# Altium schematic sheet GPS.SchDoc
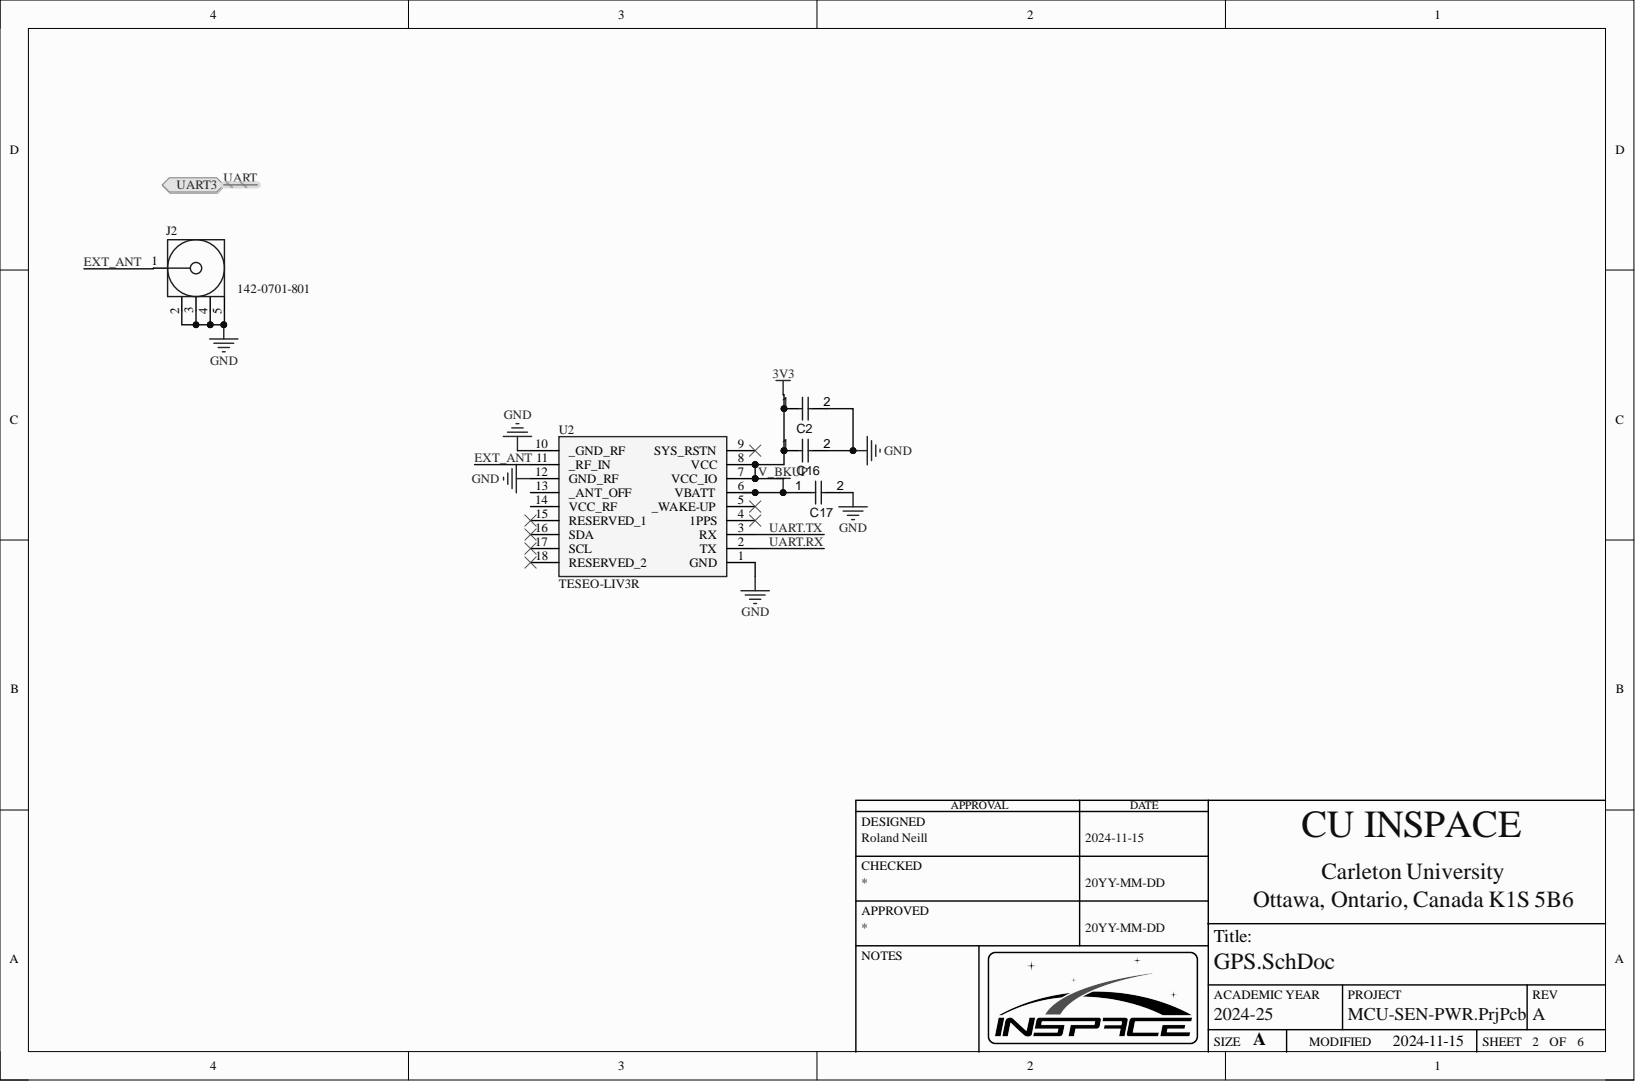
[diagram p0: GPS.SchDoc]

## Parts
C2  Capacitors  (value not drawn)
C16  Capacitors  (value not drawn)
C17  Capacitors  (value not drawn)
J2  142-0701-801  [CINC-142-0701-801_V]
U2  TESEO-LIV3R  [TESEO-LIV3R-Footprint-1]

## Ports
UART3, UART3.RX, UART3.TX

## Nets
3V3: C2.1 C16.1 U2.7(VCC_IO) U2.8(VCC)
GND: C2.2 C16.2 C17.2 J2.2 J2.3 J2.4 J2.5 U2.1(GND) U2.10(_GND_RF) U2.12(GND_RF)
V_BKUP: C17.1 U2.6(VBATT)
EXT_ANT: J2.1 U2.11(_RF_IN)
UART.RX: U2.2(TX) ; port UART3
UART.TX: U2.3(RX) ; port UART3

Not connected: U2 4(1PPS) 5(_WAKE-UP) 9(SYS_RSTN) 13(_ANT_OFF) 14(VCC_RF) 15(RESERVED_1) 16(SDA) 17(SCL) 18(RESERVED_2)

## Library data of the parts
C2 C16 C17 = Capacitors: Capacitor; Component Kind: Standard; Component Type: Capacitors; Library Name: Americas- CU InSpace-  Carleton University
J2 = CMP-2000-05490-1: SMA End Launch Jack Receptacle, 50 Ohm, 0 to 18 GHz, -65 to 165 degC, 5-Pin SMD, RoHS, Bulk; Manufacturer: EmersonConnectivitySolutions; Body Material: Brass; Body Style: Straight; Brand: Johnson/EmersonConnectivitySolutions; Connector Type: JackPCB; Gender: Jack(Female); Impedance: 50Ohms; Maximum Frequency: 18GHz; Component Kind: Standard; Contact Plating: Gold; Datasheet URL: https://belfuse.com/resources/Johnson/productinformation/pi-142-0701-801.pdf; Datasheet Version: 12/2014; Factory Pack Quantity: 5; Library Name: Altium Content Vault; Manufacturer URL: http://www.cinch.com/; Mounting Technology: SurfaceMount; Operating Temperature Range: -65Cto+165C; Orientation: Straight; Package Description: 5-Pin Surface Mount Device, Body 14.275 x 9.525 mm; Package Reference: 142-0701-801; Package URL: https://belfuse.com/resources/Johnson/drawings/dr-1420701801.pdf; Product: Connectors; Product Category: RFConnectors/CoaxialConnectors; RF Series: SMA; RoHS: Details; Series: SMA; Termination Style: Solder
U2 = CMP-021-00007-2: Telecom Circuit; Component Kind: Standard; RoHS Compliant: Yes; Library Name: Americas- CU InSpace-  Carleton University
